# Altium schematic sheet Voltage Indicator Schematic.SchDoc("Voltage Indicator Schematic")
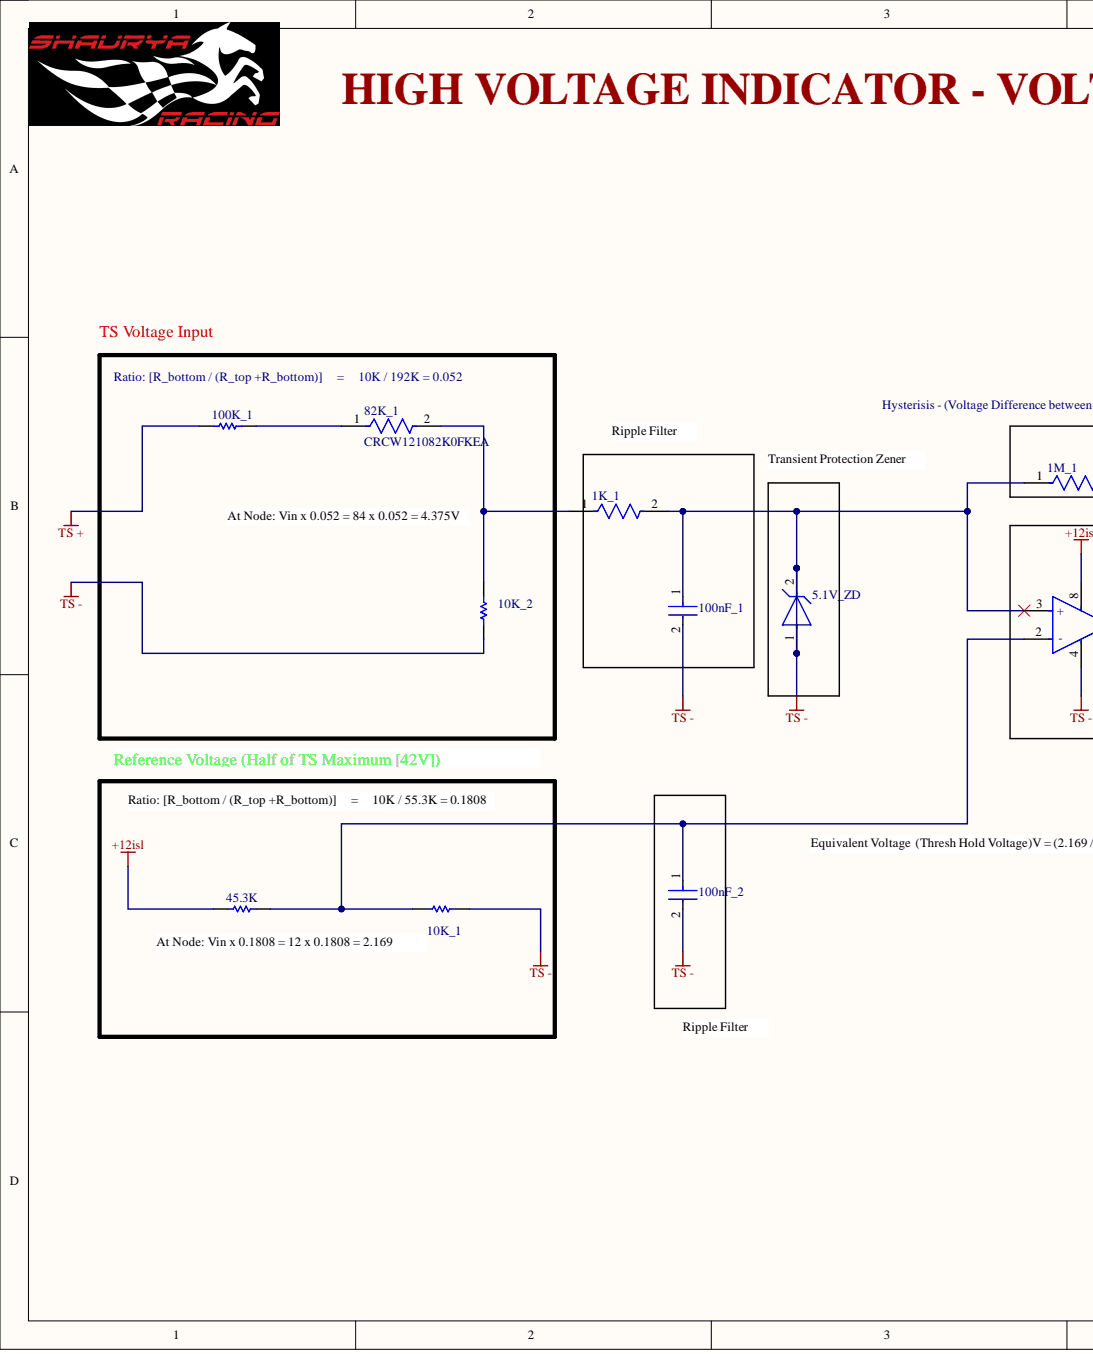
[diagram p0: Voltage Indicator Schematic.SchDoc, left]
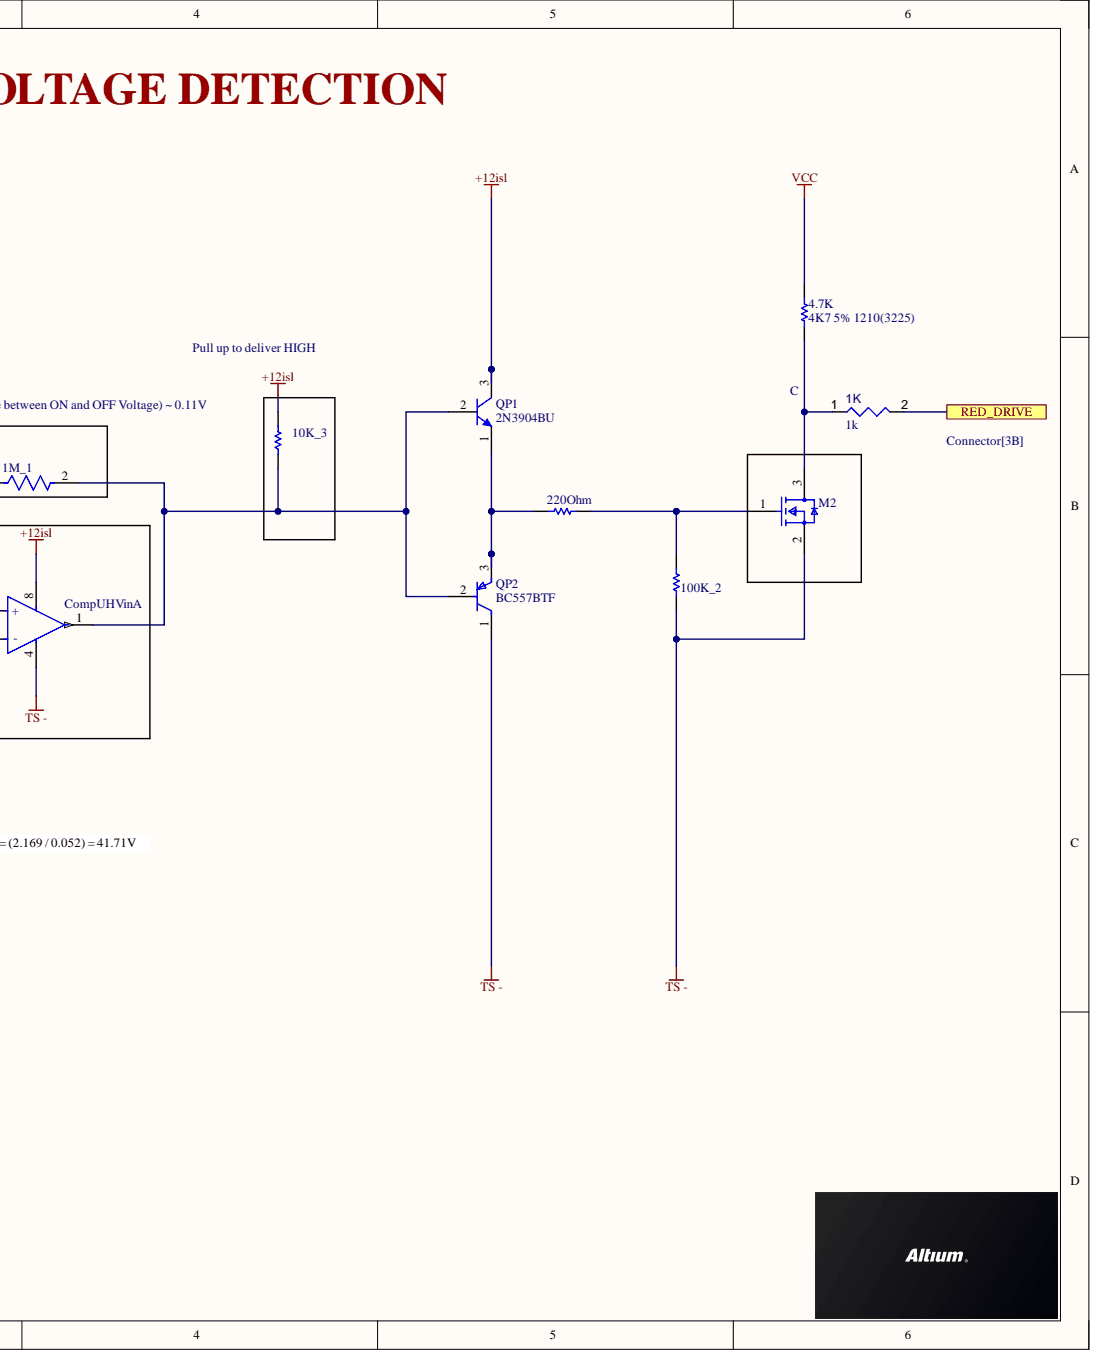
[diagram p1: Voltage Indicator Schematic.SchDoc, right]

## Parts
1K  value 1k  (hidden comment: Resistor 1K +/-5 % 0402 63 mW)
1K_1  CRCW08051K00JNEC  (value not drawn)
1M_1  MCU08050D1004BP500  (value not drawn)
4.7K  4K7 5% 1210(3225)
5.1V_ZD  BZD27C5V1P-E3-08  (value not drawn)
10K_1  TNPW121010K0BEEN  (value not drawn)
10K_2  TNPW121010K0BEEN  (value not drawn)
10K_3  TNPW121010K0BEEN  (value not drawn)
45.3K  45K3 1% 2512(6432)  (value not drawn)
82K_1  CRCW121082K0FKEA
100K_1  TNPW0805100KDEEA  (value not drawn)
100K_2  TNPW0805100KDEEA  (value not drawn)
100nF_1  C0805C104K5RACTU  (value not drawn)
100nF_2  C0805C104K5RACTU  (value not drawn)
220Ohm  220R 1% 2010(5025)  (value not drawn)
CompUHVin  LM2903BIDR  (value not drawn)  (part A)
M2  2N7002H6327XTSA2  (value not drawn)
QP1  2N3904BU
QP2  BC557BTF

## Ports
RED_DRIVE

## Nets
+12isl: 10K_3.2 45.3K.1 CompUHVin.8 QP1.3
TS +: 100K_1.1
TS -: 1K_1.2 1M_1.1 5.1V_ZD.1 5.1V_ZD.2 10K_1.2 10K_2.1 100K_2.1 100nF_1.1 100nF_1.2 100nF_2.2 CompUHVin.3(+) CompUHVin.4 M2.2 QP2.1
VCC: 4.7K.2
RED_DRIVE: 1K.2 ; port RED_DRIVE
Net1K_1: 1K.1 4.7K.1 M2.3
Net1K_1_1: 1K_1.1 10K_2.2 82K_1.2
Net1M_1_2: 1M_1.2 10K_3.1 CompUHVin.1 QP1.2 QP2.2
Net10K_1_1: 10K_1.1 45.3K.2 100nF_2.1 CompUHVin.2(-)
Net82K_1_1: 82K_1.1 100K_1.2
Net100K_2_2: 100K_2.2 220Ohm.2 M2.1
Net220Ohm_1: 220Ohm.1 QP1.1 QP2.3

## Library data of the parts
1K = CMP-009-00157-3: Chip Resistor, 1 kOhms, +/-5 %, 63 mW, -55 to 155 degC, 0402; Power: 63mW; Tolerance: 5%; Case/Package: 0402; Component Kind: Standard; Max Operating Temperature: 155°C; Min Operating Temperature: -55°C; Pins: 2; Library Name: APAC - VIT, Chennai - Shaurya Racing
1K_1 = CMP-02407-005778-1: RES Thick Film, 1kΩ, 5%, 0.125W, 200ppm/°C, 0805; Max Power Dissipation: 125mW; Resistance: 1kR; Case/Package: 0805; Component Kind: Standard; Composition: Thick Film; Contact Plating: Tin; Features: Automotive AEC-Q200; Height: 0.02inch; Lead Free: Lead Free; Length: 0.079inch; Library Name: Altium Content Vault; Max Operating Temperature: 155°C; Min Operating Temperature: -55°C; Number of Terminations: 2; Package Quantity: 20000; Packaging: Tape and Reel; Radiation Hardening: No; Temperature Coefficient: 200ppm/°C; Tolerance: 5%; Width: 0.049inch
1M_1 = CMP-02407-001361-1: RES 1.05K OHM 0.5% 1/5W 0805; Resistance: 1MR; Tolerance: 0.1%; Case/Package: 0805; Component Kind: Standard; Height: 450um; Lead Free: Lead Free; Length: 2mm; Library Name: Altium Content Vault; Width: 1.25mm
4.7K = CMP-1015-00090-1: 4K7 0.5W 5% 1210 (3225 Metric)  SMD; Case-EIA: 1210; Case-Metric: 3225; Value: 4700.00 Ohm; Component Kind: Standard; Library Name: Altium Content Vault; Power: 0.5 W; Technology: SMT; Tolerance: 5 %
5.1V_ZD = CMP-02541-000027-1: DIODE ZENER 5.1V 800MW DO219AB; Element Configuration: Single; ESD Protection: Yes; Forward Voltage: 1.2V; Impedance: 6R; Max Reverse Current: 5uA; Test Current: 100mA; Tolerance: 6%; Voltage Tolerance: 5%; Breakdown Voltage: 5.1V; Case/Package: DO-219AB; Component Kind: Standard; Contact Plating: Tin; Height: 1.08mm; Lead Free: Lead Free; Length: 2.9mm; Library Name: Altium Content Vault; Max Operating Temperature: 175°C; Max Power Dissipation: 800mW; Max Reverse Leakage Current: 5uA; Min Operating Temperature: -65°C; Mount: Surface Mount; Number of Pins: 2; Package Quantity: 3000; Packaging: Tape and Reel; RoHS Compliant: Yes; Series: Automotive, AEC-Q101, BZD27C; Width: 1.9mm; Zener Current: 5uA; Zener Voltage: 5.1V
10K_1-10K_3 = CMP-2005-00857-1: Manufacturer: Vishay Dale; Component Kind: Standard; Library Name: Altium Content Vault; Part Number: TNPW121010K0BEEN
45.3K = CMP-1018-00697-1: 45K3 1W 1% 2512 (6432 Metric)  SMD; Case-EIA: 2512; Case-Metric: 6432; Value: 45300.00 Ohm; Component Kind: Standard; Library Name: Altium Content Vault; Power: 1 W; Technology: SMT; Tolerance: 1 %
82K_1 = CMP-1015-00726-2: RES SMD 205K OHM 1% 1/2W 2203; Resistance: 82kR; Temperature Coefficient: 100ppm/°C; Voltage Rating (DC): 200V; Case/Package: 1210; Component Kind: Standard; Composition: Thick Film; Contact Plating: Tin; Height: 550um; Lead Free: Lead Free; Length: 3.2mm; Library Name: Altium Content Vault; Max Operating Temperature: 155°C; Min Operating Temperature: -55°C; Packaging: Tape and Reel; Radiation Hardening: No; Tolerance: 1%; Width: 2.5mm
100K_1 100K_2 = CMP-2001-04708-1: Manufacturer: Vishay Dale; Component Kind: Standard; Library Name: Altium Content Vault; Part Number: TNPW0805100KDEEA
100nF_1 100nF_2 = CMP-2007-00279-2: CAP CER 0.1UF 50V X7R 0805; Manufacturer: KEMET; Capacitance: 0.10 μF; Temperature Coefficient: 30ppm/°C; Tolerance: 0.1; Value: 0.10 μF; Automotive: No; Category: Cap; Component Kind: Standard; ComponentLink2Description: Datasheet; ComponentLink2URL: https://content.kemet.com/datasheets/KEM_C1003_C0G_SMD.pdf; Device Class L1: Passive Components; Device Class L2: Capacitors; Device Class L3: Ceramic Capacitors; Digikey Description: CAP CER 0.1UF 50V X7R 0805; Height: 0.88mm; Ipc Land Pattern Name: CAPC200125X78; Lead Free: Yes; Library Name: Altium Content Vault; Manufacturer Part Number: C0805C104K5RACTU; Material: Ceramic; Mouser Description: Cap Ceramic 0.1uF 50V X7R 10% SMD 2.0 x 1.2 mm 125 C Paper T/R; Octopart: https://octopart.com/c0805c104k5ractu-kemet-143926; Package: C0805C-DN; RoHS: TRUE; Temperature: -55°C to +125°C; Temperature Characteristic: X7R; Temperature Range High: +125°C; Temperature Range Low: -55°C; Voltage Rating: 50 V
220Ohm = CMP-1017-00433-1: 220R 0.75W 1% 2010 (5025 Metric)  SMD; Case-EIA: 2010; Case-Metric: 5025; Value: 220.00 Ohm; Component Kind: Standard; Library Name: Altium Content Vault; Power: 0.75 W; Technology: SMT; Tolerance: 1 %
CompUHVin = CMP-04896-000007-1: 36V JI.3 DUAL COMPARATOR; Manufacturer: Texas Instruments; Input Bias Current: -0.0035uA; Input Offset Voltage: 4mV; Max Junction Temp: +150°C; Min Supply Voltage: 3V; Nominal Supply Current: 400-550uA; Number Of Channels: 2; Output Current Per Channel: 25mA; Response Time: 1us; Standoff Height: 0.11mm; Ambient Temperature Range High: +125°C; Ambient Temperature Range Low: -40°C; Automotive: No; Category: IC; Component Kind: Standard; ComponentLink2Description: Datasheet; ComponentLink2URL: http://www.ti.com/lit/ds/symlink/lm2903b.pdf; Device Class L1: Integrated Circuits (ICs); Device Class L2: Linear ICs; Device Class L3: Comparators; Digikey Description: 36V JI.3 DUAL COMPARATOR; Height: 1.75mm; Lead Free: Yes; Library Name: Altium Content Vault; Manufacturer Part Number: LM2903BIDR; Max Supply Voltage: 36V; Octopart: https://octopart.com/lm2903bidr-texas+instruments-104977165; Package: D0008A; RoHS: TRUE; Temperature: -40°C to +125°C; Temperature Range High: +125°C; Temperature Range Low: -40°C
M2 = CMP-32901-000180-1: MOSFET N-CH 60V 0.3A SOT23; Continuous Drain Current (ID): 300mA; Gate to Source Voltage (Vgs): 20V; Input Capacitance: 20pF; Max Power Dissipation: 500mW; Rds On Max: 3R; Rise Time: 3.3ns; Case/Package: SOT-23; Component Kind: Standard; Drain to Source Voltage (Vdss): 60V; Halogen Free: Halogen Free; Lead Free: Lead Free; Library Name: Altium Content Vault; Max Dual Supply Voltage: 60V; Max Operating Temperature: 150°C; Min Operating Temperature: -55°C; Mount: Surface Mount; Number of Pins: 3; Packaging: Tape and Reel
QP1 = CMP-2000-04944-1: NPN General-Purpose Amplifier, 40 V VCEO, 0.2 A, -55 to 150 degC, 2-Pin TO-92, RoHS, Bulk; Manufacturer: FairchildSemiconductor; Maximum Collector Base Voltage: 60V; Maximum Collector Emitter Saturation Voltage: 0.2@1mA@10mA; Maximum Collector Emitter Voltage: 40V; Maximum DC Collector Current: 0.2A; Maximum Operating Frequency: 300(Min)MHz; Maximum Power Dissipation: 625mW; Minimum DC Current Gain: 40@0.1mA@1V; Rad Hard: No; Category: GPBJT; Component Kind: Standard; Datasheet URL: https://datasheet.ciiva.com/4722/2n3904-82270-4722369.pdf; Datasheet Version: Rev. 1.1.0, 10/2014; Library Name: Altium Content Vault; Manufacturer URL: https://www.fairchildsemi.com/; Mounting: ThroughHole; Operating Temperature: -55to150degC; Package: 3TO-92; Package Description: 3-Pin Transistor Outline Package, Body Dia 4.79 mm, Pitch 1.27 mm; Package Reference: TO-92; Packaging: Bulk; Pin Count: 3; Type: NPN
QP2 = CMP-00278-84408945-1: BC557BTF Small Signal Bipolar Transistor, 0.1A I(C), 45V V(BR)CEO, 1-Element, PNP, Silicon, TO-92; Manufacturer Lifecycle Status: ACTIVE; Collector Base Voltage (VCBO): -50V; Collector Emitter Saturation Voltage: -250mV; Current Rating: -100mA; Element Configuration: Single; Emitter Base Voltage (VEBO): -5V; Frequency: 150MHz; hFE Min: 110; Max Collector Current: 100mA; Max Frequency: 10MHz; Max Power Dissipation: 500mW; Number of Elements: 1; Voltage Rating (DC): -45V; Case/Package: TO-92; Collector Emitter Breakdown Voltage: 45V; Collector Emitter Voltage (VCEO): 45V; Component Kind: Standard; Contact Plating: Tin; Gain Bandwidth Product: 150MHz; Height: 5.33mm; Lead Free: Lead Free; Length: 5.2mm; Library Name: Altium Content Vault; Lifecycle Status: Production; Max Breakdown Voltage: 45V; Max Operating Temperature: 150°C; Min Operating Temperature: -65°C; Mount: Through Hole; NexarPartId: 84408945; Number of Pins: 3; Polarity: PNP; Power Dissipation: 500mW; REACH SVHC: No SVHC; RoHS: Compliant; Schedule B: 8541210080, 8541210080; Transition Frequency: 150MHz; Weight: 240mg; Width: 4.19mm
